# Altium schematic sheet Gecko_IO.SchDoc
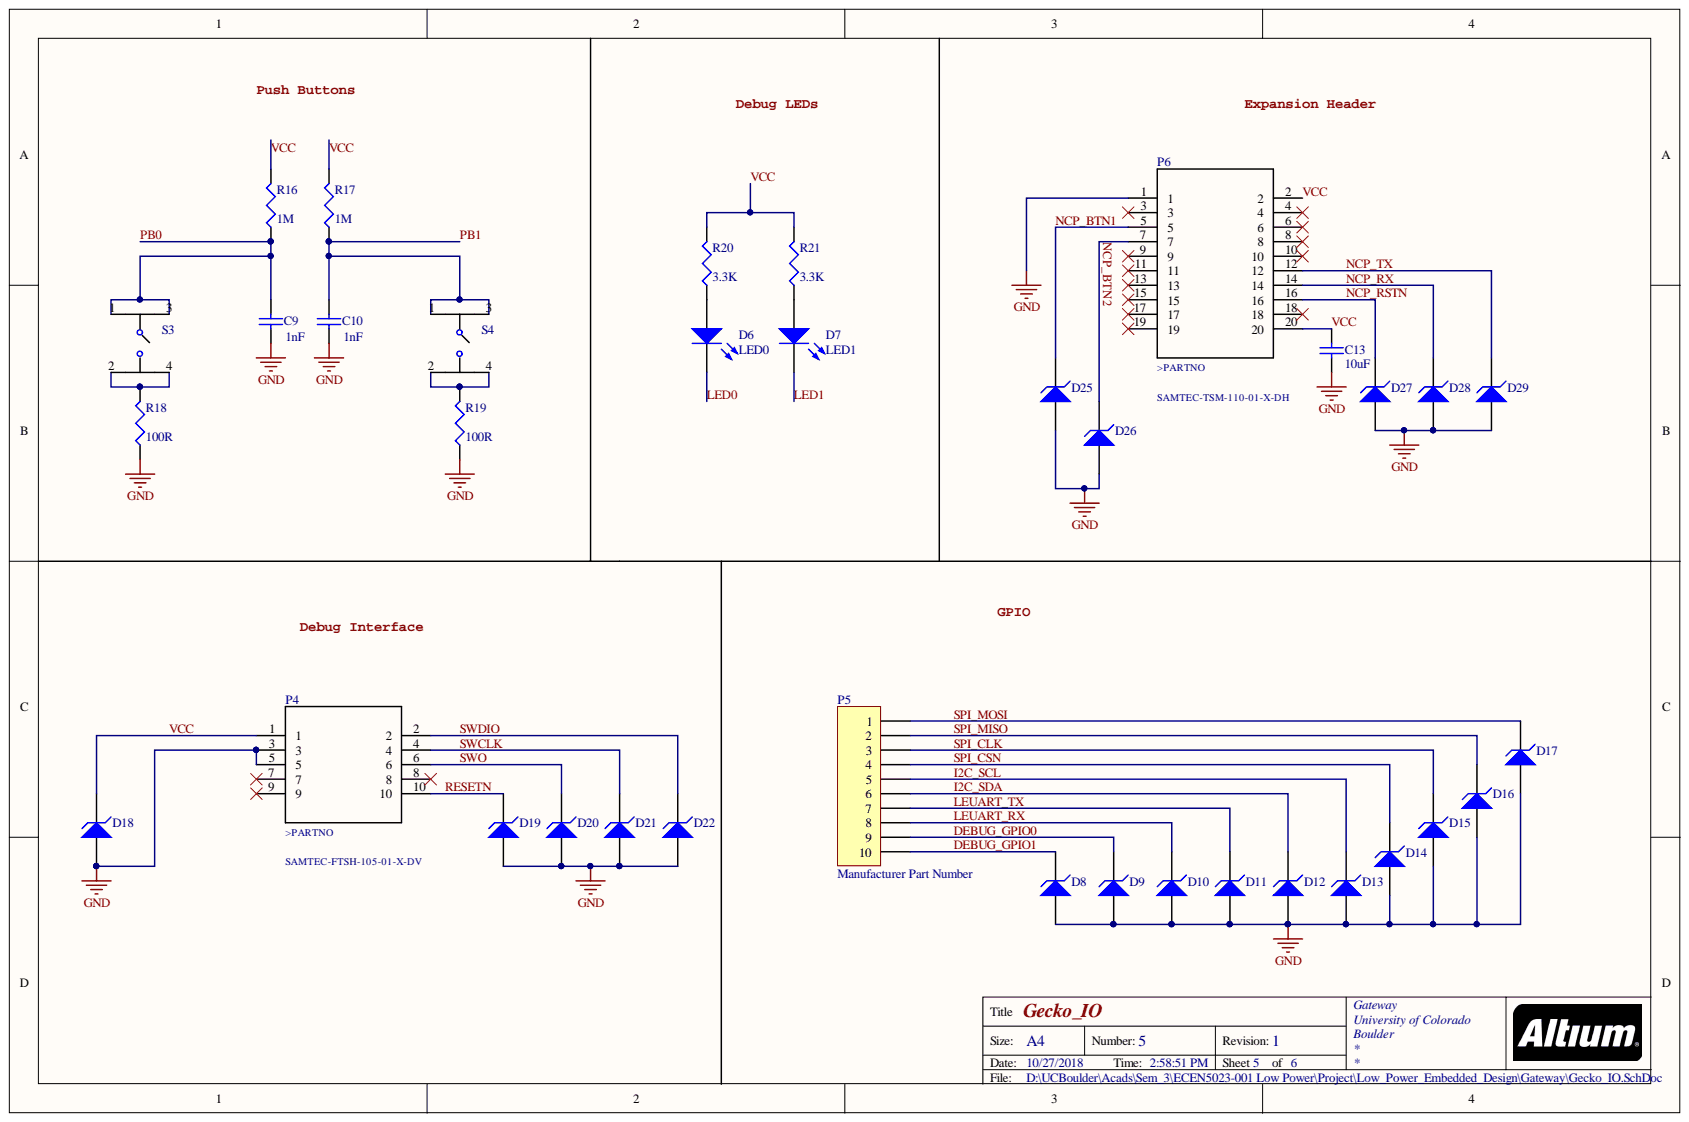
[diagram p0: Gecko_IO.SchDoc]

## Parts
C9  value 1nF  [1608[0603]]  (hidden comment: 06035C102JAT2A)
C10  value 1nF  [1608[0603]]  (hidden comment: 06035C102JAT2A)
C13  value 10uF  [1608[0603]]  (hidden comment: GRM188R61A106KE69D)
D6  LED0  [3.2X1.6X1.1]
D7  LED1  [3.2X1.6X1.1]
D8  TPD1E10B06DPYR  [0402]  (value not drawn)
D9  TPD1E10B06DPYR  [0402]  (value not drawn)
D10  TPD1E10B06DPYR  [0402]  (value not drawn)
D11  TPD1E10B06DPYR  [0402]  (value not drawn)
D12  TPD1E10B06DPYR  [0402]  (value not drawn)
D13  TPD1E10B06DPYR  [0402]  (value not drawn)
D14  TPD1E10B06DPYR  [0402]  (value not drawn)
D15  TPD1E10B06DPYR  [0402]  (value not drawn)
D16  TPD1E10B06DPYR  [0402]  (value not drawn)
D17  TPD1E10B06DPYR  [0402]  (value not drawn)
D18  D Zener  [0402]  (value not drawn)
D19  D Zener  [0402]  (value not drawn)
D20  D Zener  [0402]  (value not drawn)
D21  D Zener  [0402]  (value not drawn)
D22  D Zener  [0402]  (value not drawn)
D25  TPD1E10B06DPYR  [0402]  (value not drawn)
D26  TPD1E10B06DPYR  [0402]  (value not drawn)
D27  TPD1E10B06DPYR  [0402]  (value not drawn)
D28  TPD1E10B06DPYR  [0402]  (value not drawn)
D29  TPD1E10B06DPYR  [0402]  (value not drawn)
P4  SAMTEC-FTSH-105-01-X-DV  [SAMTEC-FTSH-105-01-X-DV]
P5  Manufacturer Part Number  [HDR1X10]
P6  TSM-110-01-L-DH-A-P-TR  [SAMTEC-TSM-110-01-X-DH]  (value not drawn)
R16  value 1M  [1608[0603]]  (hidden comment: RC1608J105CS)
R17  value 1M  [1608[0603]]  (hidden comment: RC1608J105CS)
R18  value 100R  [1608[0603]]  (hidden comment: CRCW0603100RJNEAC)
R19  value 100R  [1608[0603]]  (hidden comment: CRCW0603100RJNEAC)
R20  value 3.3K  [1608[0603]]  (hidden comment: ERJ-3GEYJ332V)
R21  value 3.3K  [1608[0603]]  (hidden comment: ERJ-3GEYJ332V)
S3  430182043816  [430182043816]  (value not drawn)
S4  430182043816  [430182043816]  (value not drawn)

## Nets
GND: C9.1 C10.1 C13.2 D8.1 D9.1 D10.1 D11.1 D12.1 D13.1 D14.1 D15.1 D16.1 D17.1 D18.1 D19.1 D20.1 D21.1 D22.1 D25.1 D26.1 D27.1 D28.1 D29.1 P4.3 P4.5 P6.1 R18.1 R19.1
DEBUG_GPIO0: D9.2 P5.9
DEBUG_GPIO1: D8.2 P5.10
I2C_SCL: D13.2 P5.5
I2C_SDA: D12.2 P5.6
LED0: D6.2
LED1: D7.2
LEUART_RX: D10.2 P5.8
LEUART_TX: D11.2 P5.7
NCP_BTN1: D25.2 P6.5
NCP_BTN2: D26.2 P6.7
NCP_RSTN: D27.2 P6.16
NCP_RX: D28.2 P6.14
NCP_TX: D29.2 P6.12
PB0: C9.2 R16.1 S3.1 S3.3
PB1: C10.2 R17.1 S4.1 S4.3
RESETN: D19.2 P4.10
SPI_CLK: D15.2 P5.3
SPI_CSN: D14.2 P5.4
SPI_MISO: D16.2 P5.2
SPI_MOSI: D17.2 P5.1
SWCLK: D21.2 P4.4
SWDIO: D22.2 P4.2
SWO: D20.2 P4.6
VCC: C13.1 D18.2 P4.1 P6.2 P6.20 R16.2 R17.2 R20.2 R21.2
NetD6_1: D6.1 R20.1
NetD7_1: D7.1 R21.1
NetR18_2: R18.2 S3.2 S3.4
NetR19_2: R19.2 S4.2 S4.4

Not connected: P4 7 8 9; P6 3 4 6 8 9 10 11 13 15 17 18 19

## Library data of the parts
C9 C10 = Cap: CAP CER 1000PF 50V X7R 0603; Manufacturer: AVX Corporation; Component Kind: Standard; ComponentLink1Description: Digi-Key Link; ComponentLink1URL: https://www.digikey.com/product-detail/en/avx-corporation/06035C102JAT2A/478-5006-1-ND/1888217; Digi-Key Part Number: 478-5006-1-ND; LatestRevisionDate: 17-Jul-2002; LatestRevisionNote: Re-released for DXP Platform.; Library Name: Miscellaneous Devices.IntLib; Manufacturer Part Number: 06035C102JAT2A; PackageDescription: Radial Cap, Thru-Hole; 2 Leads; 0.3 in Pin Spacing; PackageReference: RAD-0.3; Published: 8-Jun-2000; Signal Integrity: Cap; Simulation: CAP; Publisher: Altium Limited
C13 = Cap: CAP CER 10UF 10V X5R 0603; Manufacturer: Murata Electronics North America; Component Kind: Standard; ComponentLink1Description: Digi-Key Link; ComponentLink1URL: https://www.digikey.com/product-detail/en/murata-electronics-north-america/GRM188R61A106KE69D/490-10474-1-ND/5026392; Digi-Key Part Number: 490-10474-1-ND; LatestRevisionDate: 17-Jul-2002; LatestRevisionNote: Re-released for DXP Platform.; Library Name: Miscellaneous Devices.IntLib; Manufacturer Part Number: GRM188R61A106KE69D; PackageDescription: Radial Cap, Thru-Hole; 2 Leads; 0.3 in Pin Spacing; PackageReference: RAD-0.3; Published: 8-Jun-2000; Signal Integrity: Cap; Simulation: CAP; Publisher: Altium Limited
D6 D7 = LED2: LED GREEN DIFFUSED 0603 SMD; Manufacturer: OSRAM Opto Semiconductors Inc.; Manufacturer Part Number: LG L29K-F2J1-24-Z; Component Kind: Standard; ComponentLink1Description: Digi-Key Link; ComponentLink1URL: https://www.digikey.com/product-detail/en/osram-opto-semiconductors-inc/LG-L29K-F2J1-24-Z/475-3118-1-ND/4899549; Digi-Key Part Number: 475-3118-1-ND; Library Name: Miscellaneous Devices.IntLib; Simulation: LED2
D8-D17 D25-D29 = D Zener: Zener Diode; Manufacturer: Texas Instruments; Component Kind: Standard; ComponentLink1Description: Digi-Key Link; Digi-Key Part Number: 296-30406-1-ND; Library Name: Miscellaneous Devices.IntLib; Manufacturer Part Number: TPD1E10B06DPYR; Signal Integrity: D Zener; Simulation: ZENER
  D10-D17 D25-D29: ComponentLink1URL: https://www.digikey.com/product-detail/en/texas-instruments/TPD1E10B06DPYR/296-30406-1-ND/3283560
D18-D22 = D Zener: TVS DIODE 5.5V 14V 2X1SON; Manufacturer: Texas Instruments; Manufacturer Part Number: TPD1E10B06DPYR; Component Kind: Standard; ComponentLink1Description: Digi-Key Link; ComponentLink1URL: https://www.digikey.com/product-detail/en/texas-instruments/TPD1E10B06DPYR/296-30406-1-ND/3283560; Digi-Key Part Number: 296-30406-1-ND; Library Name: Miscellaneous Devices.IntLib; Signal Integrity: D Zener; Simulation: ZENER
P4 = SAMTEC-FTSH-105-01-X-DV: .050" X .050 TERMINAL STRIP; Manufacturer: Samtec; Manufacturer Part Number: FTSH-105-01-F-DV-K-TR; Component Kind: Standard; ComponentLink1Description: Digi-Key Link; ComponentLink1URL: https://www.digikey.com/products/en/connectors-interconnects/rectangular-connectors-headers-male-pins/314?k=FTSH-105-01-F-DV-K-TR&k=&pkeyword=FTSH-105-01-F-DV-K-TR&pv7=2&sf=0&quantity=&ColumnSort=0&page=1&pageSize=25; Digi-Key Part Number: SAM13160CT-ND; Library Name: Debug_connector.SchLib
P5 = Header 10: Header, 10-Pin; Component Kind: Standard; LatestRevisionDate: 17-Jul-2002; LatestRevisionNote: Re-released for DXP Platform.; Library Name: Miscellaneous Connectors.IntLib; Signal Integrity: Connector; Publisher: Altium Limited
P6 = SAMTEC-TSM-110-01-X-DH: .025 SQ. TERMINAL STRIPS; Manufacturer: Samtec Inc.; Component Kind: Standard; ComponentLink1Description: Digi-Key Link; ComponentLink1URL: https://www.digikey.com/product-detail/en/samtec-inc/TSM-110-01-L-DH-A-P-TR/SAM14883CT-ND/9595175; Digi-Key Part Number: SAM14883CT-ND; Library Name: SAMTEC-TSM-110-01-X-DH.SchLib; Manufacturer Part Number: TSM-110-01-L-DH-A-P-TR
R16 R17 = Res3: RES SMD 1M OHM 5% 1/10W 0603; Manufacturer: Samsung Electro-Mechanics; Component Kind: Standard; ComponentLink1Description: Digi-Key Link; ComponentLink1URL: https://www.digikey.com/product-detail/en/samsung-electro-mechanics/RC1608J105CS/1276-5134-1-ND/3968106; Digi-Key Part Number: 1276-5134-1-ND; LatestRevisionDate: 29-May-2009; LatestRevisionNote: IPC-7351 Footprint Added.; Library Name: Miscellaneous Devices.IntLib; Manufacturer Part Number: RC1608J105CS; PackageDescription: Chip Resistor; PackageReference: J1-0603; Published: 8-Jun-2000; Signal Integrity: Res; Simulation: RESISTOR; Publisher: Altium Limited
R18 R19 = Res3: RES SMD 100 OHM 5% 1/10W 0603; Manufacturer: Vishay Dale; Component Kind: Standard; ComponentLink1Description: Digi-Key Link; ComponentLink1URL: https://www.digikey.com/product-detail/en/vishay-dale/CRCW0603100RJNEAC/541-4059-1-ND/7928742; Digi-Key Part Number: 541-4059-1-ND; LatestRevisionDate: 29-May-2009; LatestRevisionNote: IPC-7351 Footprint Added.; Library Name: Miscellaneous Devices.IntLib; Manufacturer Part Number: CRCW0603100RJNEAC; PackageDescription: Chip Resistor; PackageReference: J1-0603; Published: 8-Jun-2000; Signal Integrity: Res; Simulation: RESISTOR; Publisher: Altium Limited
R20 R21 = Res3: RES SMD 3.3K OHM 5% 1/10W 0603; Manufacturer: Panasonic Electronic Components; Component Kind: Standard; ComponentLink1Description: Digi-Key URL; ComponentLink1URL: https://www.digikey.com/product-detail/en/panasonic-electronic-components/ERJ-3GEYJ332V/P3.3KGCT-ND/135146; Digi-Key Part Number: P3.3KGCT-ND; Library Name: Miscellaneous Devices.IntLib; Manufacturer Part Number: ERJ-3GEYJ332V; Signal Integrity: Res; Simulation: RESISTOR
S3 S4 = 430182043816: SWITCH TACTILE SPST-NO 0.05A 12V; Manufacturer: Wurth Electronics; Component Kind: Standard; ComponentLink1Description: Digi-Key Link; ComponentLink1URL: https://www.digikey.com/products/en/switches/tactile-switches/197?k=430+182+043+816&k=&pkeyword=430+182+043+816&pv7=2&sf=0&quantity=&ColumnSort=0&page=1&pageSize=25; Digi-Key Part Number: 732-7004-1-ND; Library Name: 430182043816.SchLib; Manufacturer Part Number: 430182043816
